# Altium schematic sheet STM32.SchDoc(STM32)
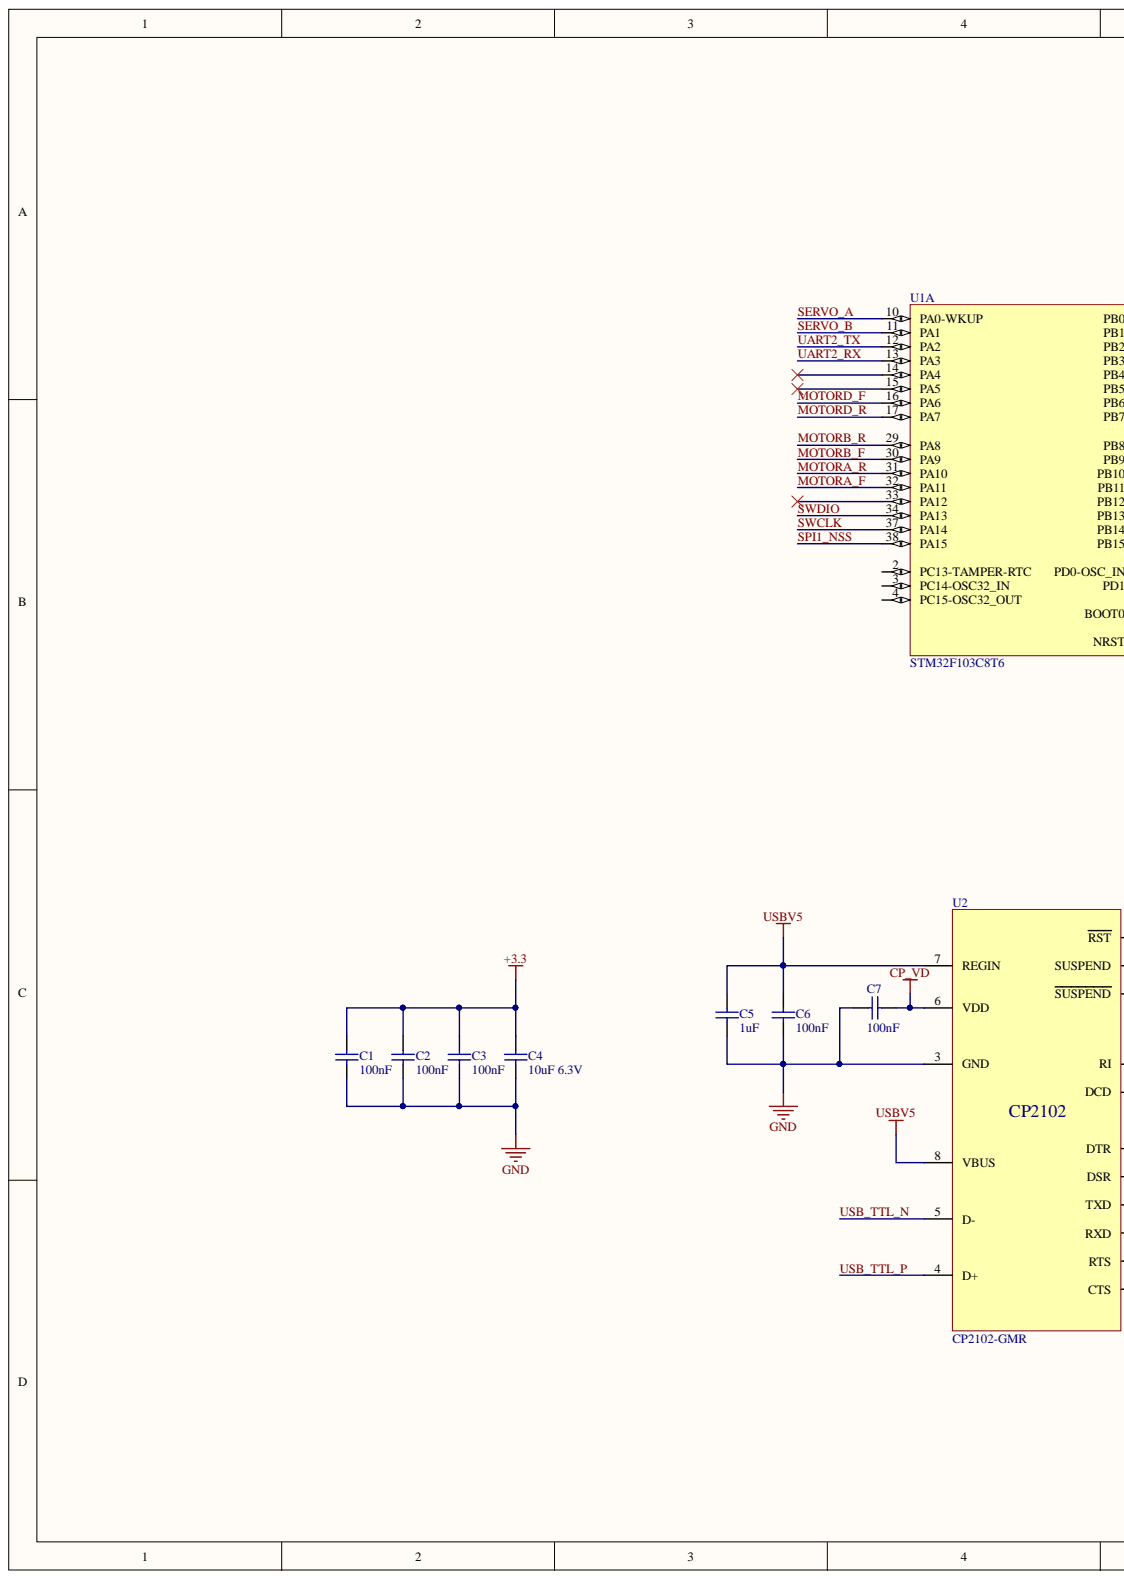
[diagram p0: STM32.SchDoc, left]
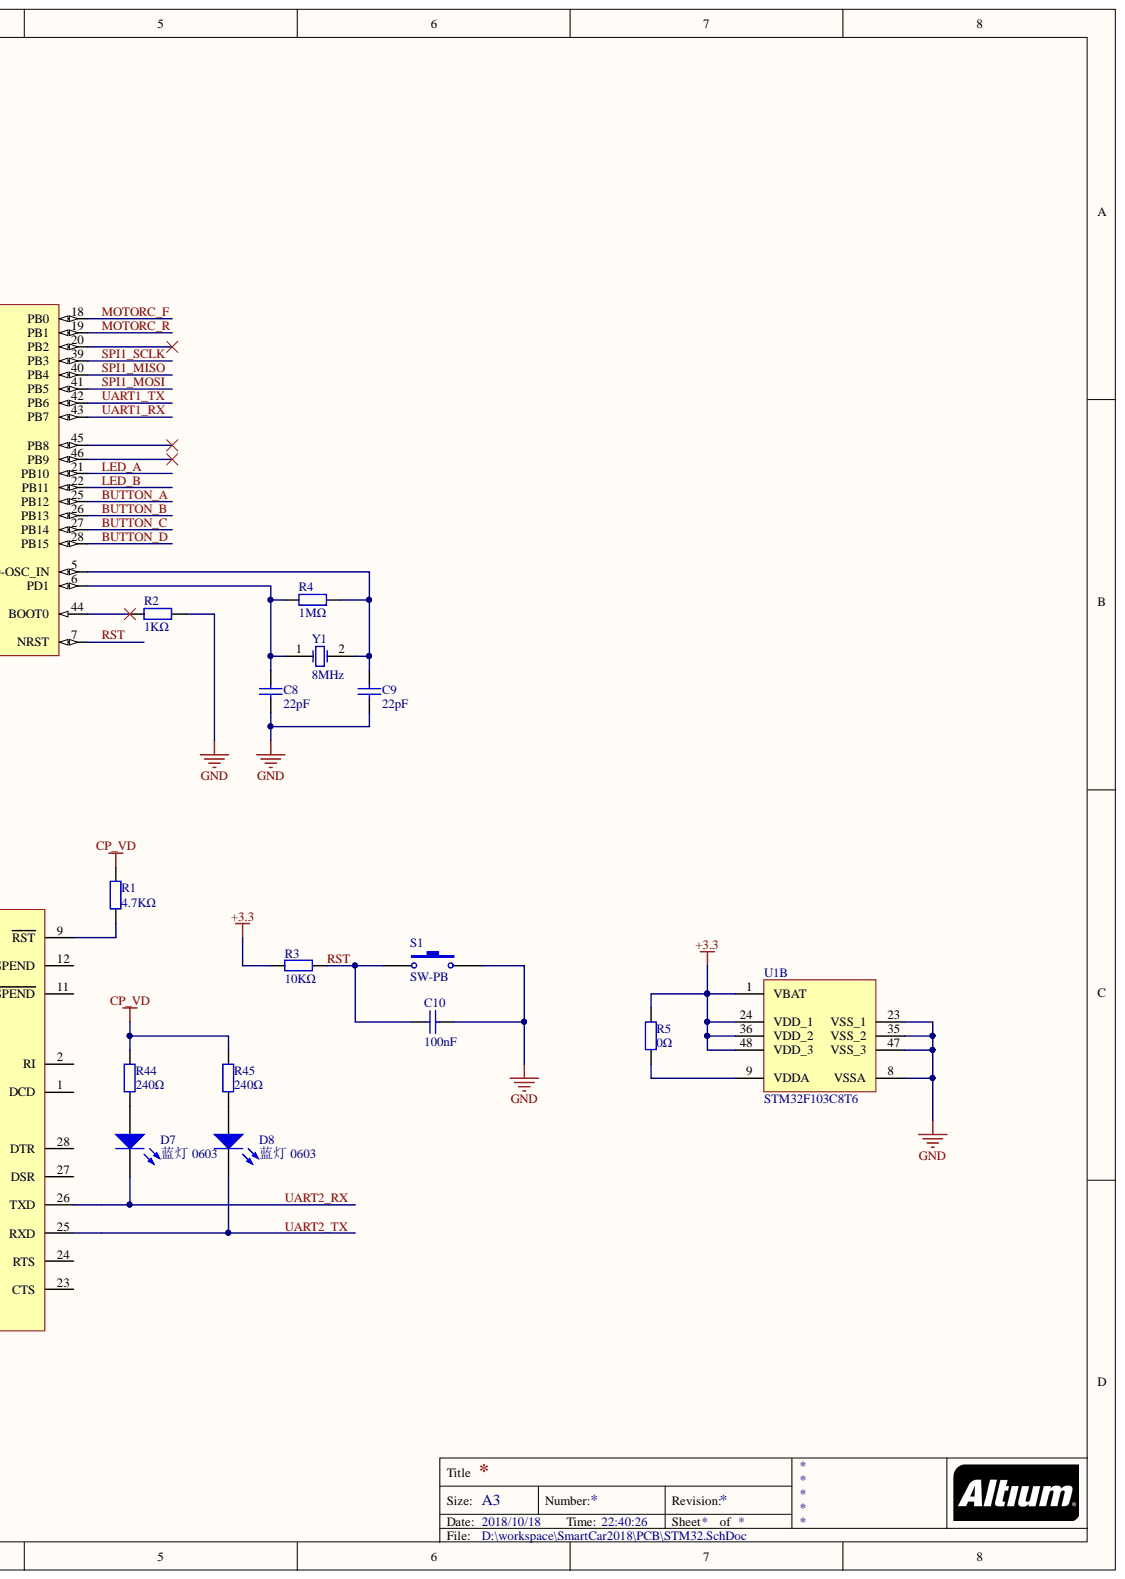
[diagram p1: STM32.SchDoc, right]

## Parts
C1  100nF  [0402_C]
C2  100nF  [0402_C]
C3  100nF  [0402_C]
C4  10uF 6.3V  [0402_C]
C5  1uF  [0603_C]
C6  100nF  [0603_C]
C7  100nF  [0603_C]
C8  22pF  [0603_C]
C9  22pF  [0603_C]
C10  100nF  [0603_C]
D7  蓝灯 0603  [0603_LED_S1]
D8  蓝灯 0603  Package: 0603  [0603_LED_S1]
R1  4.7KΩ  [0603_R]
R2  1KΩ  [0603_R]
R3  10KΩ  [0603_R]
R4  1MΩ  [0603_R]
R5  0Ω  [0603_R]
R44  240Ω  [0603_R]
R45  240Ω  [0603_R]
S1  SW-PB  [SW_PB2_A1]
U1  STM32F103C8T6  [LQFP-48_7x7x05P]  (parts A,B)
U2  CP2102-GMR  [QFN-28_5x5x05P]
Y1  8MHz  [SMD-5032_2P]

## Nets
+3.3: C1.2 C2.2 C3.2 C4.2 R3.1 R5.2 U1.1(VBAT) U1.24(VDD_1) U1.36(VDD_2) U1.48(VDD_3)
CP_VD: C7.1 R1.2 R44.2 R45.2 U2.6(VDD)
GND: C1.1 C2.1 C3.1 C4.1 C5.1 C6.1 C7.2 C8.1 C9.1 C10.2 R2.2 S1.2 U1.8(VSSA) U1.23(VSS_1) U1.35(VSS_2) U1.47(VSS_3) U2.3(GND)
USBV5: C5.2 C6.2 U2.7(REGIN) U2.8(VBUS)
BUTTON_A: U1.25(PB12)
BUTTON_B: U1.26(PB13)
BUTTON_C: U1.27(PB14)
BUTTON_D: U1.28(PB15)
LED_A: U1.21(PB10)
LED_B: U1.22(PB11)
MOTORA_F: U1.32(PA11)
MOTORA_R: U1.31(PA10)
MOTORB_F: U1.30(PA9)
MOTORB_R: U1.29(PA8)
MOTORC_F: U1.18(PB0)
MOTORC_R: U1.19(PB1)
MOTORD_F: U1.16(PA6)
MOTORD_R: U1.17(PA7)
RST: C10.1 R3.2 S1.1 U1.7(NRST)
SERVO_A: U1.10(PA0-WKUP)
SERVO_B: U1.11(PA1)
SPI1_MISO: U1.40(PB4)
SPI1_MOSI: U1.41(PB5)
SPI1_NSS: U1.38(PA15)
SPI1_SCLK: U1.39(PB3)
SWCLK: U1.37(PA14)
SWDIO: U1.34(PA13)
UART1_RX: U1.43(PB7)
UART1_TX: U1.42(PB6)
UART2_RX: D7.K U1.13(PA3) U2.26(TXD)
UART2_TX: D8.K U1.12(PA2) U2.25(RXD)
USB_TTL_N: U2.5(D-)
USB_TTL_P: U2.4(D+)
NetC8_2: C8.2 R4.2 U1.6(PD1) Y1.1
NetC9_2: C9.2 R4.1 U1.5(PD0-OSC_IN) Y1.2
NetD7_A: D7.A R44.1
NetD8_A: D8.A R45.1
NetR1_1: R1.1 U2.9(RST)
NetR2_1: R2.1 U1.44(BOOT0)
NetR5_1: R5.1 U1.9(VDDA)

Not connected: U1 2(PC13-TAMPER-RTC) 3(PC14-OSC32_IN) 4(PC15-OSC32_OUT) 14(PA4) 15(PA5) 20(PB2) 33(PA12) 45(PB8) 46(PB9); U2 1(DCD) 2(RI) 11(SUSPEND) 12(SUSPEND) 23(CTS) 24(RTS) 27(DSR) 28(DTR)

## Library data of the parts
C1-C3 = 0402 100nF (104) 10% 16V: 贴片电容; SuppliersPartNumber: C1525; Supplier: LC; Package: 0402; Library Name: Cap.SchLib; ComponentLink1URL: http://www.szlcsc.com/product/details_1877.html; ComponentLink1Description: 供应商链接; Component Kind: Standard
C4 = 0402 10uF (106) 20% 6.3V: 贴片电容; SuppliersPartNumber: C15525; Supplier: LC; Package: 0402; Library Name: Cap.SchLib; ComponentLink1URL: http://www.szlcsc.com/product/details_16204.html; ComponentLink1Description: 供应商链接; Component Kind: Standard
C5 = 0603 1uF (105) 10% 50V: 贴片电容; SuppliersPartNumber: C15849; Supplier: LC; Package: 0603; Library Name: Cap.SchLib; ComponentLink1URL: http://www.szlcsc.com/product/details_16531.html; ComponentLink1Description: 供应商链接; Component Kind: Standard
C6 C7 C10 = 0603 100nF (104) 10% 50V: 贴片电容; SuppliersPartNumber: C14663; Supplier: LC; Package: 0603; Library Name: Cap.SchLib; ComponentLink1URL: http://www.szlcsc.com/product/details_15331.html; ComponentLink1Description: 供应商链接; Component Kind: Standard
C8 C9 = 0603 22pF (220) 5% 50V: 贴片电容; SuppliersPartNumber: C1653; Supplier: LC; Package: 0603; Library Name: Cap.SchLib; ComponentLink1URL: http://www.szlcsc.com/product/details_2005.html; ComponentLink1Description: 供应商链接; Component Kind: Standard
D7 D8 = 0603 蓝灯: 发光二极管; SuppliersPartNumber: C72041; Supplier: LC; Library Name: LED.SchLib; ComponentLink1URL: http://www.szlcsc.com/product/details_73150.html; ComponentLink1Description: 供应商链接; Component Kind: Standard
  D7: Package: 0603
R1 = 0603 4.7KΩ (4701) 1%: 贴片电阻; SuppliersPartNumber: C23162; Supplier: LC; Package: 0603; Library Name: RES.SchLib; ComponentLink1URL: http://www.szlcsc.com/product/details_23889.html; ComponentLink1Description: 供应商链接; Component Kind: Standard
R2 = 0603 1KΩ (1001) 1%: 贴片电阻; SuppliersPartNumber: C21190; Supplier: LC; Package: 0603; Library Name: RES.SchLib; ComponentLink1URL: http://www.szlcsc.com/product/details_21904.html; ComponentLink1Description: 供应商链接; Component Kind: Standard
R3 = 0603 10KΩ (1002) 1%: 贴片电阻; SuppliersPartNumber: C25804; Supplier: LC; Package: 0603; Library Name: RES.SchLib; ComponentLink1URL: http://www.szlcsc.com/product/details_26547.html; ComponentLink1Description: 供应商链接; Component Kind: Standard
R4 = 0603 1MΩ (1004) 1%: 贴片电阻; SuppliersPartNumber: C22935; Supplier: LC; Package: 0603; Library Name: RES.SchLib; ComponentLink1URL: http://www.szlcsc.com/product/details_23662.html; ComponentLink1Description: 供应商链接; Component Kind: Standard
R5 = 0603 0Ω (0R0) 1%: 贴片电阻; SuppliersPartNumber: C21189; Supplier: LC; Package: 0603; Library Name: RES.SchLib; ComponentLink1URL: http://www.szlcsc.com/product/details_21903.html; ComponentLink1Description: 供应商链接; Component Kind: Standard
R44 R45 = 0603 240Ω (2400) 1%: 贴片电阻; SuppliersPartNumber: C23350; Supplier: LC; Package: 0603; Library Name: RES.SchLib; ComponentLink1URL: http://www.szlcsc.com/product/details_24083.html; ComponentLink1Description: 供应商链接; Component Kind: Standard
S1 = SW-PB: 微动开关; Published: 24-Mar-1999; PackageReference: SPST-2; LatestRevisionNote: Re-released for DXP Platform.; LatestRevisionDate: 17-Jul-2002; Component Kind: Standard; Publisher: Altium Limited; Library Name: 主集成库.IntLib
U1 = STM32F103C8T6: ARM; SuppliersPartNumber: C8734; Supplier: LC; Package: LQFP-48_7x7x05P; Library Name: MCU.SchLib; ComponentLink1URL: http://www.szlcsc.com/product/details_9243.html; ComponentLink1Description: 供应商链接; Component Kind: Standard
U2 = CP2102-GMR_[C6568]: USB; SuppliersPartNumber: C6568; Supplier: LC; Package: QFN-28_5x5x05P; Library Name: IC.SchLib; ComponentLink1URL: http://www.szlcsc.com/product/details_7033.html; ComponentLink1Description: 供应商链接; Component Kind: Standard
Y1 = SMD-5032_2P 8MHz 20ppm 20pF: 贴片晶振; SuppliersPartNumber: C115962; Supplier: LC; Package: SMD-5032_2P; Library Name: CrystalOscillator.SchLib; ComponentLink1URL: http://www.szlcsc.com/product/details_117214.html; ComponentLink1Description: 供应商链接; Component Kind: Standard
